# Altium schematic sheet 000339.SchDoc(000339)
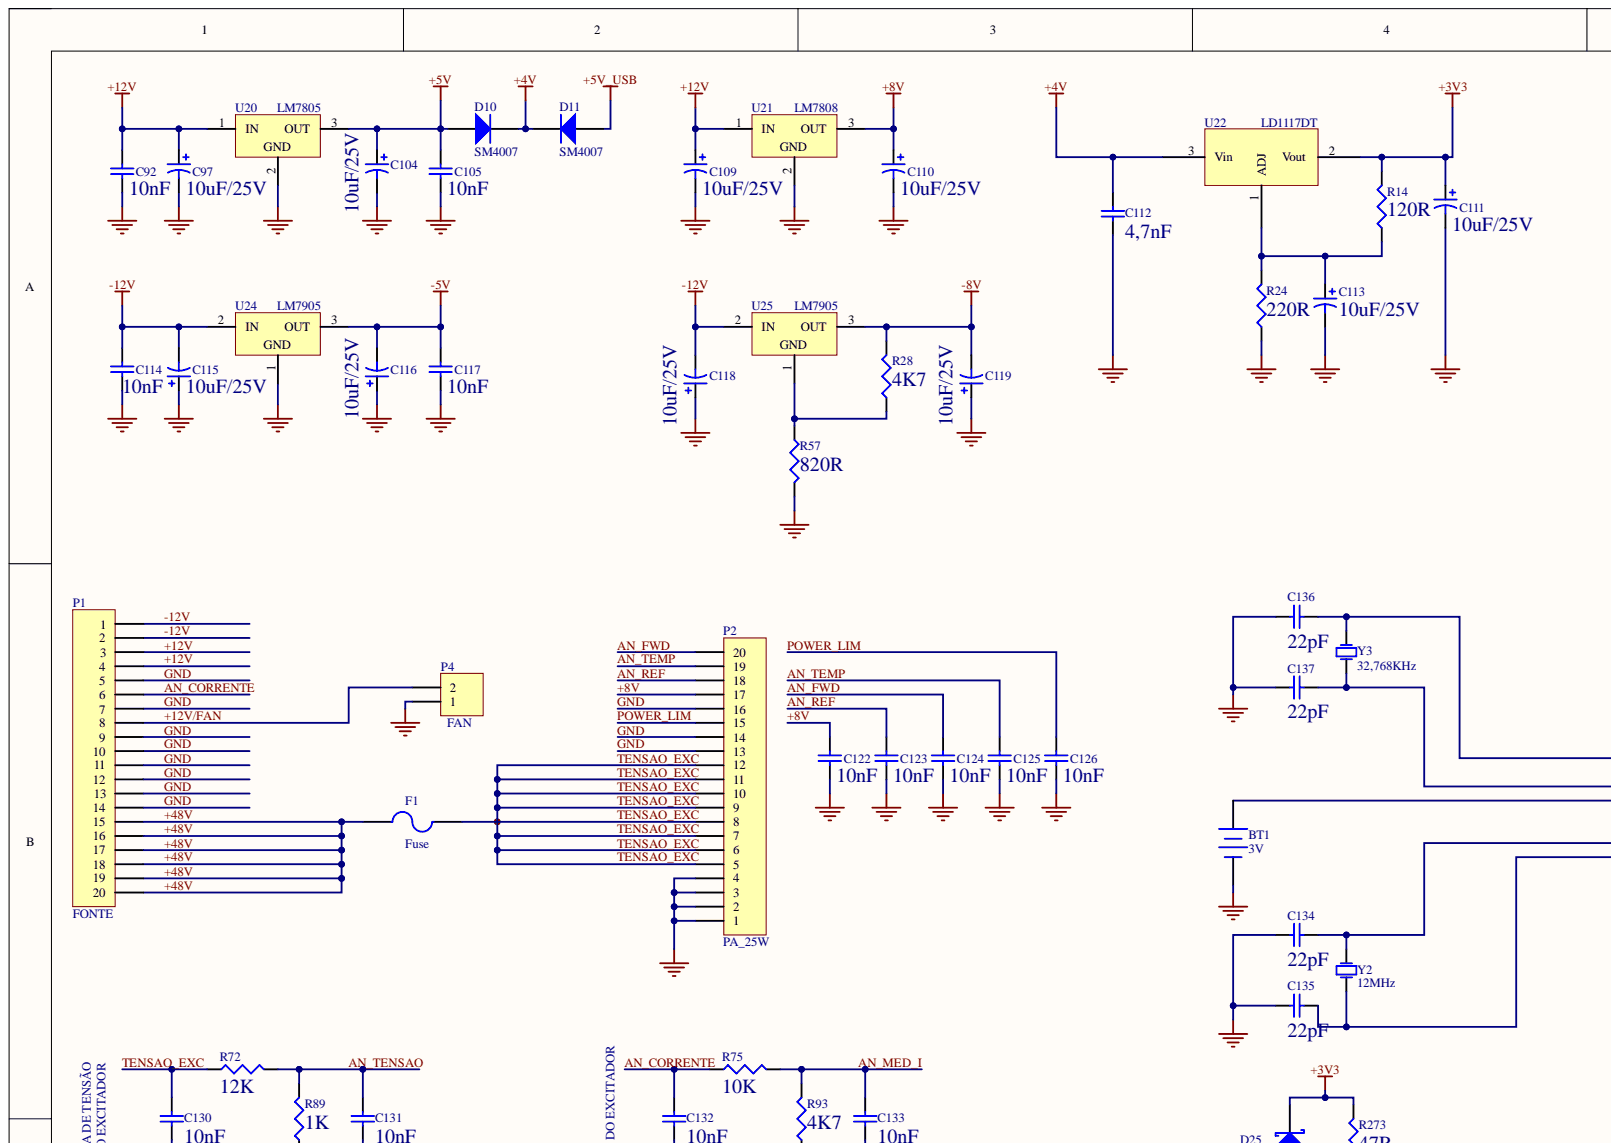
[diagram p0: 000339.SchDoc, top left]
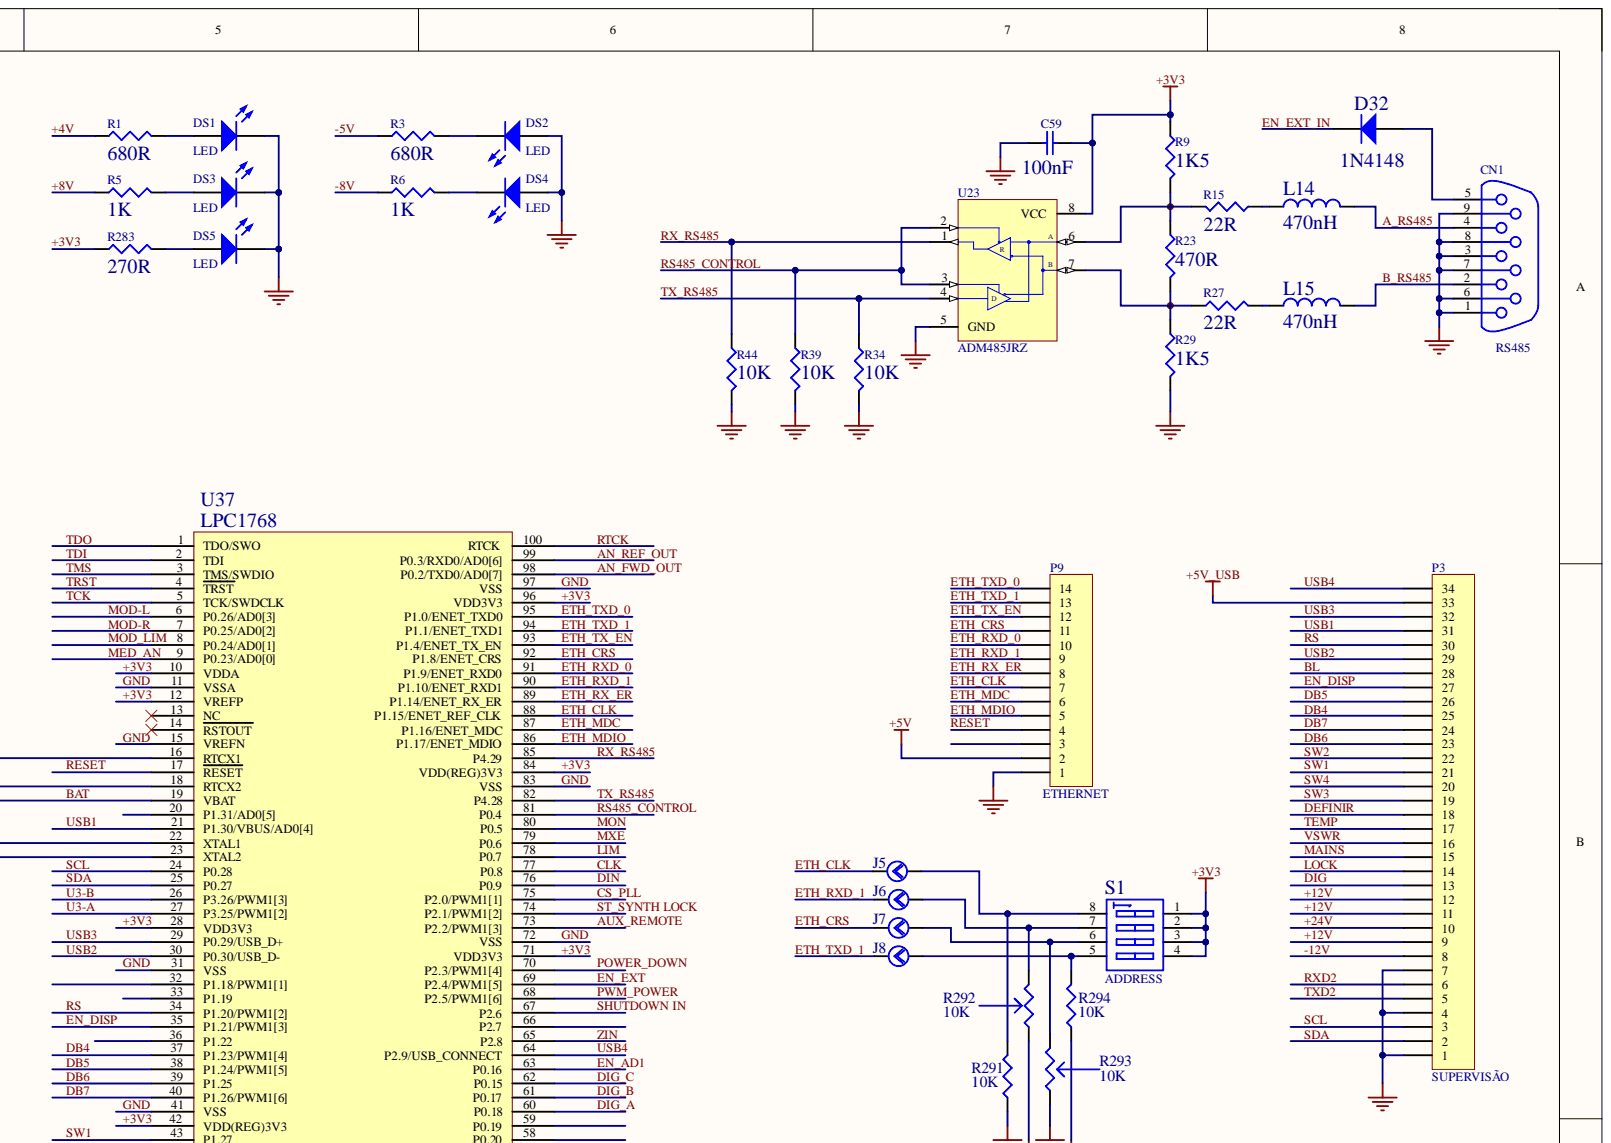
[diagram p1: 000339.SchDoc, top right]
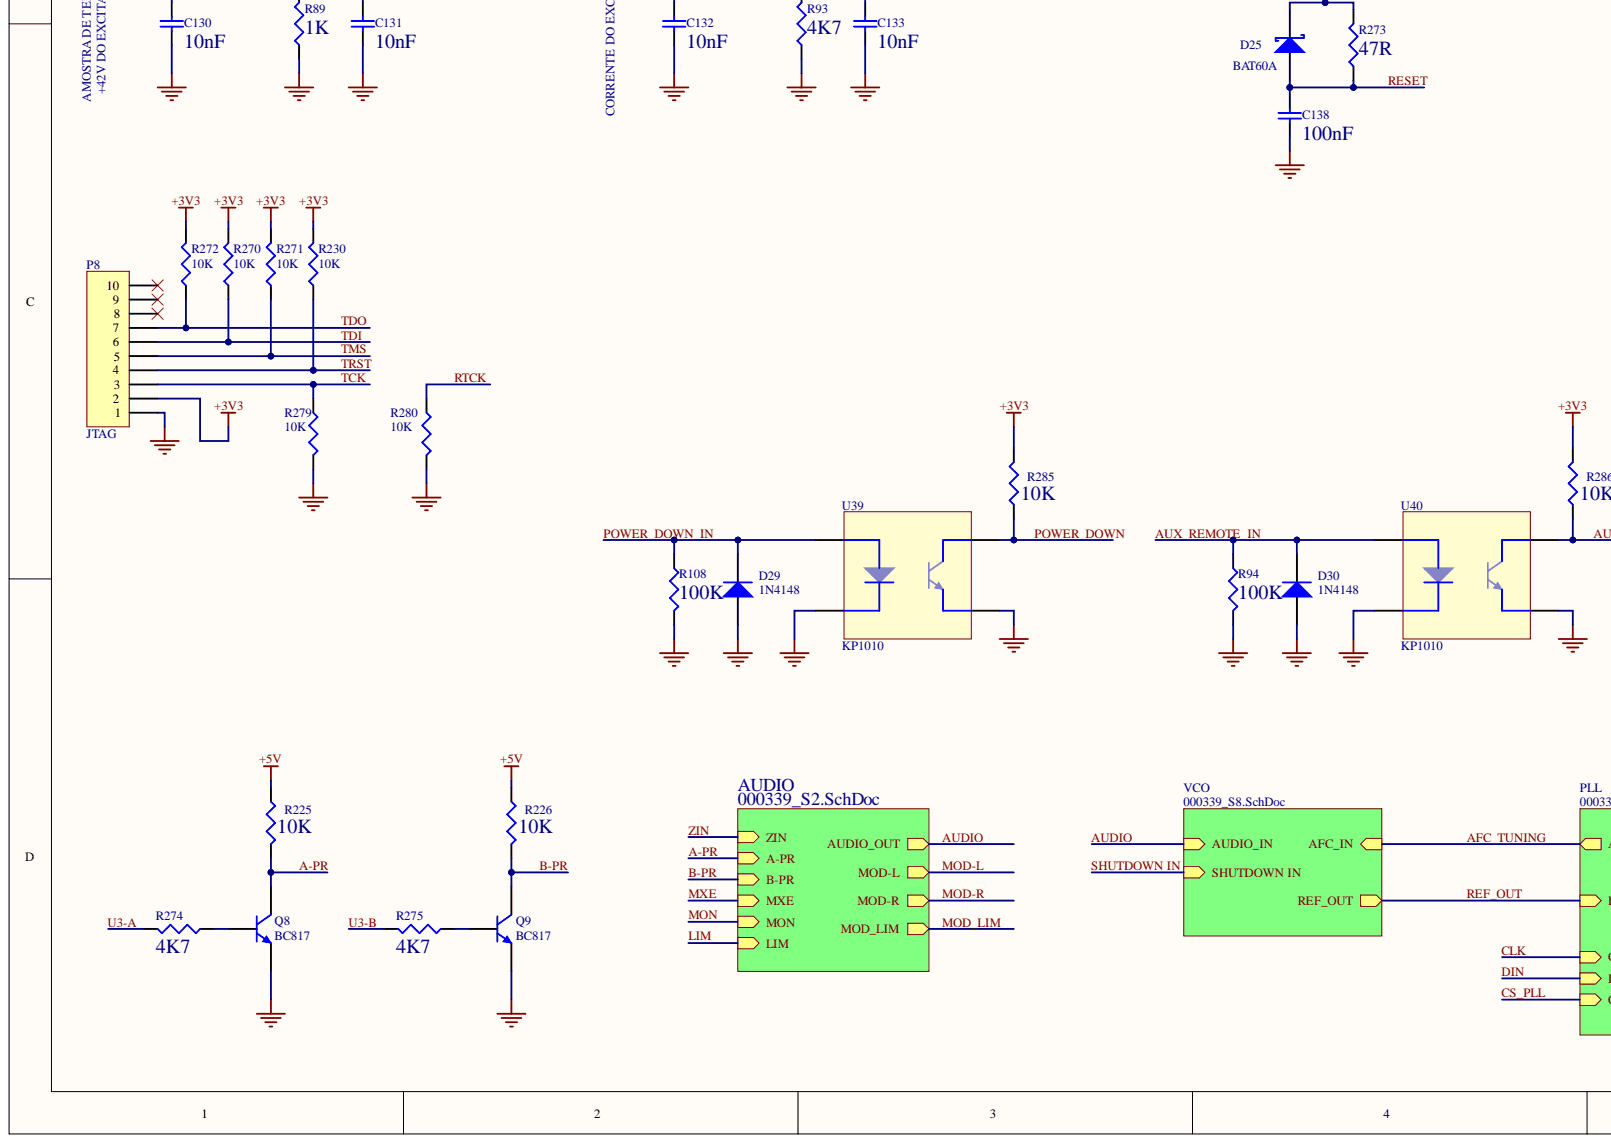
[diagram p2: 000339.SchDoc, bottom left]
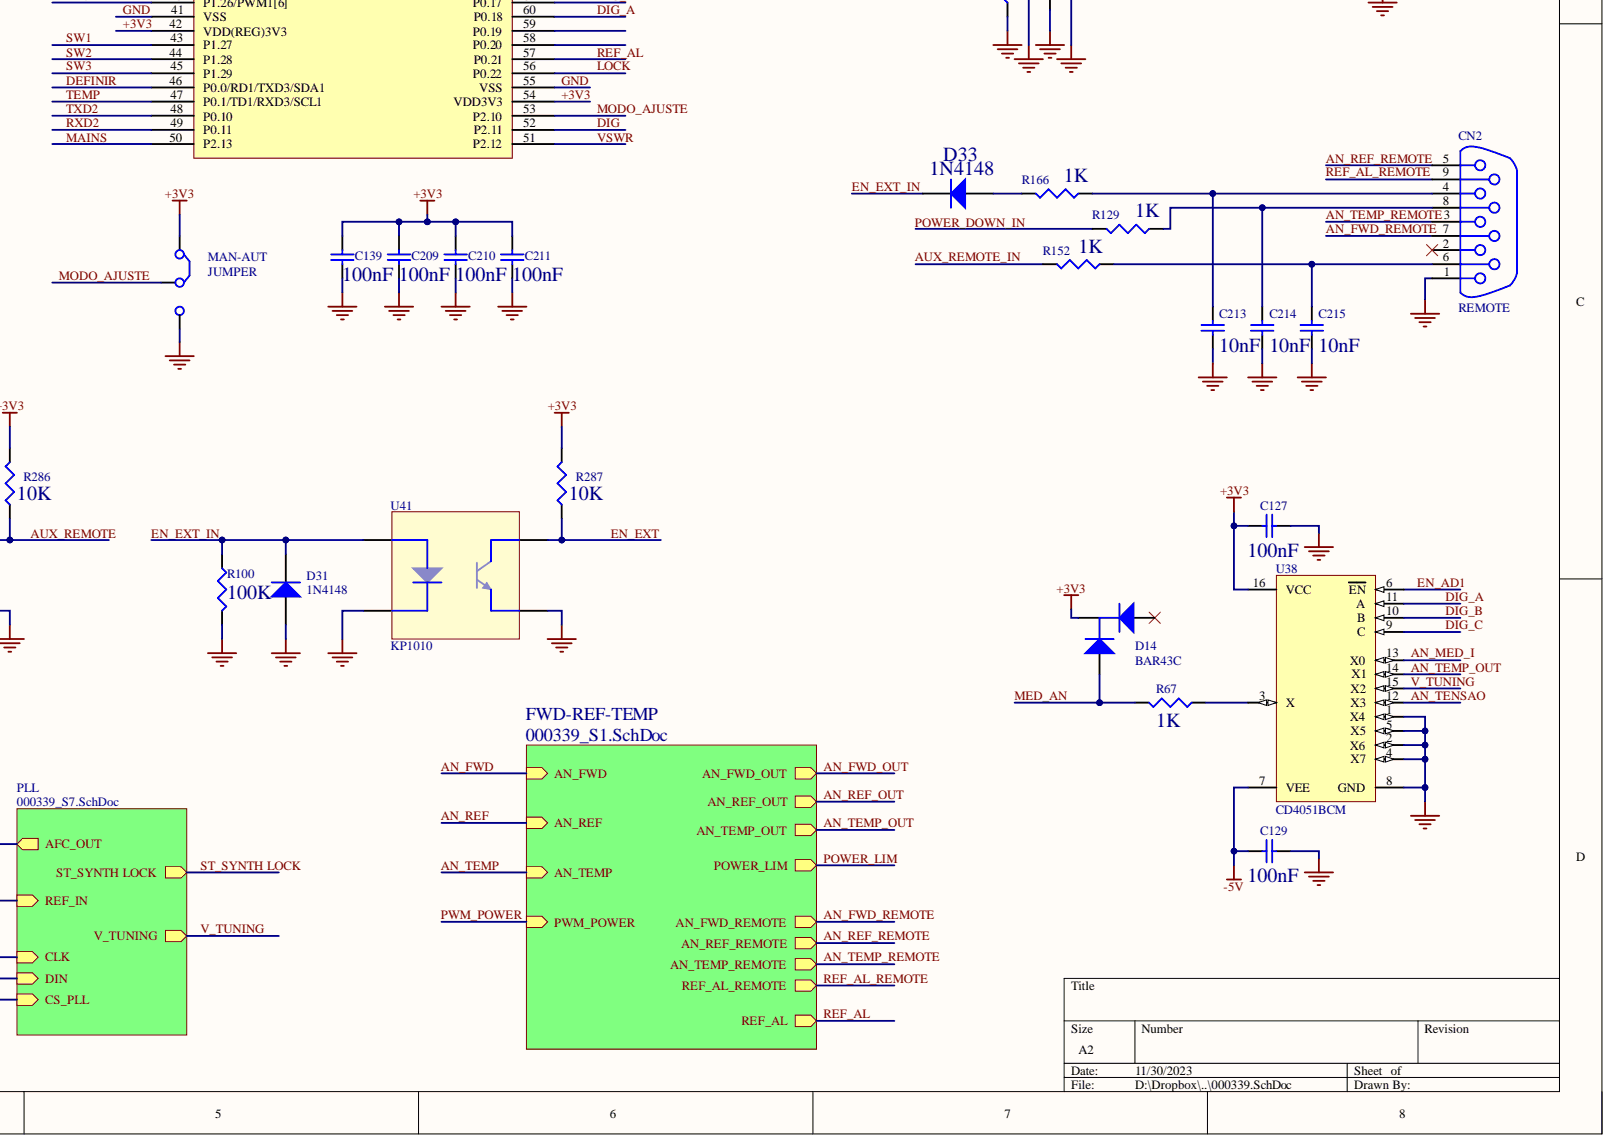
[diagram p3: 000339.SchDoc, bottom right]

## Parts
BT1  3V  [batery holder]
C59  value 100nF  [1206 - Capacitor]  (hidden comment: Capacitor)
C92  value 10nF  [1206 - Capacitor]  (hidden comment: Capacitor)
C97  value 10uF/25V  [ELETROLITICO C5x10]  (hidden comment: Capacitor Polarizado)
C104  value 10uF/25V  [ELETROLITICO C5x10]  (hidden comment: Capacitor Polarizado)
C105  value 10nF  [1206 - Capacitor]  (hidden comment: Capacitor)
C109  value 10uF/25V  [ELETROLITICO C5x10]  (hidden comment: Capacitor Polarizado)
C110  value 10uF/25V  [ELETROLITICO C5x10]  (hidden comment: Capacitor Polarizado)
C111  value 10uF/25V  [ELETROLITICO C5x10]  (hidden comment: Capacitor Polarizado)
C112  value 4,7nF  [1206 - Capacitor]  (hidden comment: Capacitor)
C113  value 10uF/25V  [ELETROLITICO C5x10]  (hidden comment: Capacitor Polarizado)
C114  value 10nF  [1206 - Capacitor]  (hidden comment: Capacitor)
C115  value 10uF/25V  [ELETROLITICO C5x10]  (hidden comment: Capacitor Polarizado)
C116  value 10uF/25V  [ELETROLITICO C5x10]  (hidden comment: Capacitor Polarizado)
C117  value 10nF  [1206 - Capacitor]  (hidden comment: Capacitor)
C118  value 10uF/25V  [ELETROLITICO C5x10]  (hidden comment: Capacitor Polarizado)
C119  value 10uF/25V  [ELETROLITICO C5x10]  (hidden comment: Capacitor Polarizado)
C122  value 10nF  [1206 - Capacitor]  (hidden comment: Capacitor)
C123  value 10nF  [1206 - Capacitor]  (hidden comment: Capacitor)
C124  value 10nF  [1206 - Capacitor]  (hidden comment: Capacitor)
C125  value 10nF  [1206 - Capacitor]  (hidden comment: Capacitor)
C126  value 10nF  [1206 - Capacitor]  (hidden comment: Capacitor)
C127  value 100nF  [1206 - Capacitor]  (hidden comment: Capacitor)
C129  value 100nF  [1206 - Capacitor]  (hidden comment: Capacitor)
C130  value 10nF  [1206 - Capacitor]  (hidden comment: Capacitor)
C131  value 10nF  [1206 - Capacitor]  (hidden comment: Capacitor)
C132  value 10nF  [1206 - Capacitor]  (hidden comment: Capacitor)
C133  value 10nF  [1206 - Capacitor]  (hidden comment: Capacitor)
C134  value 22pF  [1206 - Capacitor]  (hidden comment: Capacitor)
C135  value 22pF  [1206 - Capacitor]  (hidden comment: Capacitor)
C136  value 22pF  [1206 - Capacitor]  (hidden comment: Capacitor)
C137  value 22pF  [1206 - Capacitor]  (hidden comment: Capacitor)
C138  value 100nF  [1206 - Capacitor]  (hidden comment: Capacitor)
C139  value 100nF  [1206 - Capacitor]  (hidden comment: Capacitor)
C209  value 100nF  [1206 - Capacitor]  (hidden comment: Capacitor)
C210  value 100nF  [1206 - Capacitor]  (hidden comment: Capacitor)
C211  value 100nF  [1206 - Capacitor]  (hidden comment: Capacitor)
C213  value 10nF  [1206 - Capacitor]  (hidden comment: Capacitor)
C214  value 10nF  [1206 - Capacitor]  (hidden comment: Capacitor)
C215  value 10nF  [1206 - Capacitor]  (hidden comment: Capacitor)
CN1  RS485  [DB 9 FEMEA 90G]
CN2  REMOTE  [DB 9 FEMEA 90G]
D10  value SM4007  PART #: SM4007  [DO-213AB]  (hidden comment: MRA/SM4007)
D11  value SM4007  PART #: SM4007  [DO-213AB]  (hidden comment: MRA/SM4007)
D14  BAR43C  [SOT23]
D25  BAT60A  [SOD323]
D29  1N4148  [1N4148 - SMD]
D30  1N4148  [1N4148 - SMD]
D31  1N4148  [1N4148 - SMD]
D32  1N4148  [1N4148 - SMD]
D33  1N4148  [1N4148 - SMD]
DS1  LED  [LED 1206]
DS2  LED  [LED 1206]
DS3  LED  [LED 1206]
DS4  LED  [LED 1206]
DS5  LED  [LED 1206]
F1  Fuse  [PORTA FUSIVEL]
J5  JUNCTION  [JUNCTION]  (value not drawn)
J6  JUNCTION  [JUNCTION]  (value not drawn)
J7  JUNCTION  [JUNCTION]  (value not drawn)
J8  JUNCTION  [JUNCTION]  (value not drawn)
L14  value 470nH  [1210 Indutor]  (hidden comment: Inductor Iron)
L15  value 470nH  [1210 Indutor]  (hidden comment: Inductor Iron)
MAN-AUT  JUMPER  [HDR1X3]
P1  FONTE  [IDC 20 VIAS 180 GRAUS]
P2  PA_25W  [IDC 20 VIAS 180 GRAUS]
P3  SUPERVISÃO  [IDC 34 VIAS 180 GRAUS]
P4  FAN  [KRE_2_VIAS]
P8  JTAG  [HDR2X5]
P9  ETHERNET  [HDR2X7]
Q8  BC817  [SOT23]
Q9  BC817  [SOT23]
R1  value 680R  [1206 - Resistor]  (hidden comment: Resistor)
R3  value 680R  [1206 - Resistor]  (hidden comment: Resistor)
R5  value 1K  [1206 - Resistor]  (hidden comment: Resistor)
R6  value 1K  [1206 - Resistor]  (hidden comment: Resistor)
R9  value 1K5  [1206 - Resistor]  (hidden comment: Resistor)
R14  value 120R  [1206 - Resistor]  (hidden comment: Resistor)
R15  value 22R  [1206 - Resistor]  (hidden comment: Resistor)
R23  value 470R  [1206 - Resistor]  (hidden comment: Resistor)
R24  value 220R  [1206 - Resistor]  (hidden comment: Resistor)
R27  value 22R  [1206 - Resistor]  (hidden comment: Resistor)
R28  value 4K7  [1206 - Resistor]  (hidden comment: Resistor)
R29  value 1K5  [1206 - Resistor]  (hidden comment: Resistor)
R34  value 10K  [1206 - Resistor]  (hidden comment: Resistor)
R39  value 10K  [1206 - Resistor]  (hidden comment: Resistor)
R44  value 10K  [1206 - Resistor]  (hidden comment: Resistor)
R57  value 820R  [1206 - Resistor]  (hidden comment: Resistor)
R67  value 1K  [1206 - Resistor]  (hidden comment: Resistor)
R72  value 12K  [1206 - Resistor]  (hidden comment: Resistor)
R75  value 10K  [1206 - Resistor]  (hidden comment: Resistor)
R89  value 1K  [1206 - Resistor]  (hidden comment: Resistor)
R93  value 4K7  [1206 - Resistor]  (hidden comment: Resistor)
R94  value 100K  [1206 - Resistor]  (hidden comment: Resistor)
R100  value 100K  [1206 - Resistor]  (hidden comment: Resistor)
R108  value 100K  [1206 - Resistor]  (hidden comment: Resistor)
R129  value 1K  [1206 - Resistor]  (hidden comment: Resistor)
R152  value 1K  [1206 - Resistor]  (hidden comment: Resistor)
R166  value 1K  [1206 - Resistor]  (hidden comment: Resistor)
R225  value 10K  [1206 - Resistor]  (hidden comment: Resistor)
R226  value 10K  [1206 - Resistor]  (hidden comment: Resistor)
R230  value 10K  [1206 - Resistor]  (hidden comment: Resistor)
R270  value 10K  [1206 - Resistor]  (hidden comment: Resistor)
R271  value 10K  [1206 - Resistor]  (hidden comment: Resistor)
R272  value 10K  [1206 - Resistor]  (hidden comment: Resistor)
R273  value 47R  [1206 - Resistor]  (hidden comment: Resistor)
R274  value 4K7  [1206 - Resistor]  (hidden comment: Resistor)
R275  value 4K7  [1206 - Resistor]  (hidden comment: Resistor)
R279  value 10K  [1206 - Resistor]  (hidden comment: Resistor)
R280  value 10K  [1206 - Resistor]  (hidden comment: Resistor)
R283  value 270R  [1206 - Resistor]  (hidden comment: Resistor)
R285  value 10K  [1206 - Resistor]  (hidden comment: Resistor)
R286  value 10K  [1206 - Resistor]  (hidden comment: Resistor)
R287  value 10K  [1206 - Resistor]  (hidden comment: Resistor)
R291  value 10K  [1206 - Resistor]  (hidden comment: Resistor)
R292  value 10K  [1206 - Resistor]  (hidden comment: Resistor)
R293  value 10K  [1206 - Resistor]  (hidden comment: Resistor)
R294  value 10K  [1206 - Resistor]  (hidden comment: Resistor)
S1  ADDRESS  [DIPSWICH-4]
U20  LM7805  [TO-220AB]
U21  LM7808  [TO-220AB]
U22  LD1117DT  [LD1117DT]
U23  ADM485JRZ  [SO8 - 3D]
U24  LM7905  [TO-220AB]
U25  LM7905  [TO-220AB]
U37  LPC1768  [LPC1768-FBD100]
U38  CD4051BCM  [M16A]
U39  KP1010  [SOP-4]
U40  KP1010  [SOP-4]
U41  KP1010  [SOP-4]
Y2  12MHz  [HC-49/U]
Y3  32,768KHz  [XTAL 32.768 Khz]

## Nets
+5V: C104.1 C105.2 D10.1 P9.2 R225.1 R226.1 U20.3
+5V_USB: D11.1 P3.33
+3V3: C59.1 C111.1 C127.2 C139.2 C209.2 C210.2 C211.2 D14.3 D25.1 MAN-AUT.1 P8.2 R9.2 R14.2 R230.2 R270.2 R271.2 R272.2 R273.2 R283.1 R285.2 R286.2 R287.2 S1.1 S1.2 S1.3 S1.4 U22.2 U23.8(VCC) U37.10(VDDA) U37.12(VREFP) U37.28(VDD3V3) U37.42(VDD(REG)3V3) U37.54(VDD3V3) U37.71(VDD3V3) U37.84(VDD(REG)3V3) U37.96(VDD3V3) U38.16(VCC)
+4V: C112.2 D10.2 D11.2 R1.1 U22.3
+8V: C110.1 C122.2 P2.17 R5.1 U21.3
+12V: C92.2 C97.1 C109.1 P1.3 P1.4 P3.9 P3.11 P3.12 U20.1 U21.1
+12V/FAN: P1.8 P4.2
+24V: P3.10
+48V: F1.1 P1.15 P1.16 P1.17 P1.18 P1.19 P1.20
-5V: C116.2 C117.2 C129.1 R3.1 U24.3 U38.7(VEE)
-8V: C119.2 R6.1 R28.2 U25.3
-12V: C114.2 C115.2 C118.2 P1.1 P1.2 P3.8 U24.2 U25.2
A-PR: Q8.3 R225.2
A_RS485: CN1.4 L14.2
AN_CORRENTE: C132.2 P1.6 R75.1
AN_FWD: C124.2 P2.20
AN_FWD_OUT: U37.98(P0.2/TXD0/AD0[7])
AN_FWD_REMOTE: CN2.7
AN_MED_I: C133.2 R75.2 R93.2 U38.13(X0)
AN_REF: C123.2 P2.18
AN_REF_OUT: U37.99(P0.3/RXD0/AD0[6])
AN_REF_REMOTE: CN2.5
AN_TEMP: C125.2 P2.19
AN_TEMP_OUT: U38.14(X1)
AN_TEMP_REMOTE: CN2.3
AN_TENSAO: C131.2 R72.2 R89.2 U38.12(X3)
AUX_REMOTE: R286.1 U37.73(P2.2/PWM1[3]) U40.4
AUX_REMOTE_IN: D30.2 R94.2 R152.1 U40.1
B-PR: Q9.3 R226.2
B_RS485: CN1.2 L15.2
BAT: BT1.1 U37.19(VBAT)
BL: P3.28
CLK: U37.77(P0.8)
CS_PLL: U37.75(P2.0/PWM1[1])
DB4: P3.25 U37.37(P1.23/PWM1[4])
DB5: P3.26 U37.38(P1.24/PWM1[5])
DB6: P3.23 U37.39(P1.25)
DB7: P3.24 U37.40(P1.26/PWM1[6])
DEFINIR: P3.18 U37.46(P0.0/RD1/TXD3/SDA1)
DIG: P3.13 U37.52(P2.11)
DIG_A: U37.60(P0.18) U38.11(A)
DIG_B: U37.61(P0.17) U38.10(B)
DIG_C: U37.62(P0.15) U38.9(C)
DIN: U37.76(P0.9)
EN_AD1: U37.63(P0.16) U38.6(EN)
EN_DISP: P3.27 U37.35(P1.21/PWM1[3])
EN_EXT: R287.1 U37.69(P2.4/PWM1[5]) U41.4
EN_EXT_IN: D31.2 D32.2 D33.2 R100.2 U41.1
ETH_CLK: J5.2 P9.7 U37.88(P1.15/ENET_REF_CLK)
ETH_CRS: J7.2 P9.11 U37.92(P1.8/ENET_CRS)
ETH_MDC: P9.6 U37.87(P1.16/ENET_MDC)
ETH_MDIO: P9.5 U37.86(P1.17/ENET_MDIO)
ETH_RX_ER: P9.8 U37.89(P1.14/ENET_RX_ER)
ETH_RXD_0: P9.10 U37.91(P1.9/ENET_RXD0)
ETH_RXD_1: J6.2 P9.9 U37.90(P1.10/ENET_RXD1)
ETH_TX_EN: P9.12 U37.93(P1.4/ENET_TX_EN)
ETH_TXD_0: P9.14 U37.95(P1.0/ENET_TXD0)
ETH_TXD_1: J8.2 P9.13 U37.94(P1.1/ENET_TXD1)
GND: BT1.2 C59.2 C92.1 C97.2 C104.2 C105.1 C109.2 C110.2 C111.2 C112.1 C113.2 C114.1 C115.1 C116.1 C117.1 C118.1 C119.1 C122.1 C123.1 C124.1 C125.1 C126.1 C127.1 C129.2 C130.1 C131.1 C132.1 C133.1 C134.2 C135.2 C136.2 C137.2 C138.2 C139.1 C209.1 C210.1 C211.1 C213.1 C214.1 C215.1 CN1.1 CN1.3 CN1.6 CN1.7 CN1.8 CN1.9 CN2.1 D29.1 D30.1 D31.1 DS1.2 DS2.1 DS3.2 DS4.1 DS5.2 MAN-AUT.3 P1.5 P1.7 P1.9 P1.10 P1.11 P1.12 P1.13 P1.14 P2.1 P2.2 P2.3 P2.4 P2.13 P2.14 P2.16 P3.1 P3.4 P3.7 P4.1 P8.1 P9.1 Q8.2 Q9.2 R24.1 R29.1 R34.1 R39.1 R44.1 R57.2 R89.1 R93.1 R94.1 R100.1 R108.1 R279.2 R280.2 R291.2 R292.2 R293.2 R294.2 U20.2 U21.2 U23.5(GND) U24.1 U37.11(VSSA) U37.15(VREFN) U37.31(VSS) U37.41(VSS) U37.55(VSS) U37.72(VSS) U37.83(VSS) U37.97(VSS) U38.1(X4) U38.2(X6) U38.4(X7) U38.5(X5) U38.8(GND) U39.2 U39.3 U40.2 U40.3 U41.2 U41.3
LIM: U37.78(P0.7)
LOCK: P3.14 U37.56(P0.22)
MAINS: P3.15 U37.50(P2.13)
MED_AN: D14.1 R67.1 U37.9(P0.23/AD0[0])
MOD-L: U37.6(P0.26/AD0[3])
MOD-R: U37.7(P0.25/AD0[2])
MOD_LIM: U37.8(P0.24/AD0[1])
MODO_AJUSTE: MAN-AUT.2 U37.53(P2.10)
MON: U37.80(P0.5)
MXE: U37.79(P0.6)
POWER_DOWN: R285.1 U37.70(P2.3/PWM1[4]) U39.4
POWER_DOWN_IN: D29.2 R108.2 R129.1 U39.1
POWER_LIM: C126.2 P2.15
PWM_POWER: U37.68(P2.5/PWM1[6])
REF_AL: U37.57(P0.21)
REF_AL_REMOTE: CN2.9
RESET: C138.1 D25.2 P9.4 R273.1 U37.17(RESET)
RS: P3.30 U37.34(P1.20/PWM1[2])
RS485_CONTROL: R39.2 U23.2 U23.3 U37.81(P0.4)
RTCK: R280.1 U37.100(RTCK)
RX_RS485: R44.2 U23.1 U37.85(P4.29)
RXD2: P3.6 U37.49(P0.11)
SCL: P3.3 U37.24(P0.28)
SDA: P3.2 U37.25(P0.27)
SHUTDOWN IN: U37.67(P2.6)
ST_SYNTH LOCK: U37.74(P2.1/PWM1[2])
SW1: P3.21 U37.43(P1.27)
SW2: P3.22 U37.44(P1.28)
SW3: P3.19 U37.45(P1.29)
SW4: P3.20
TCK: P8.3 R279.1 U37.5(TCK/SWDCLK)
TDI: P8.6 R270.1 U37.2(TDI)
TDO: P8.7 R272.1 U37.1(TDO/SWO)
TEMP: P3.17 U37.47(P0.1/TD1/RXD3/SCL1)
TENSAO_EXC: C130.2 F1.2 P2.5 P2.6 P2.7 P2.8 P2.9 P2.10 P2.11 P2.12 R72.1
TMS: P8.5 R271.1 U37.3(TMS/SWDIO)
TRST: P8.4 R230.1 U37.4(TRST)
TX_RS485: R34.2 U23.4 U37.82(P4.28)
TXD2: P3.5 U37.48(P0.10)
U3-A: R274.2 U37.27(P3.25/PWM1[2])
U3-B: R275.2 U37.26(P3.26/PWM1[3])
USB1: P3.31 U37.21(P1.30/VBUS/AD0[4])
USB2: P3.29 U37.30(P0.30/USB_D-)
USB3: P3.32 U37.29(P0.29/USB_D+)
USB4: P3.34 U37.64(P2.9/USB_CONNECT)
V_TUNING: U38.15(X2)
VSWR: P3.16 U37.51(P2.12)
ZIN: U37.65(P2.8)
NetC113_1: C113.1 R14.1 R24.2 U22.1
NetC134_1: C134.1 U37.22(XTAL1) Y2.2
NetC135_1: C135.1 U37.23(XTAL2) Y2.1
NetC136_1: C136.1 U37.16(RTCX1) Y3.2
NetC137_1: C137.1 U37.18(RTCX2) Y3.1
NetC213_2: C213.2 CN2.4 R166.2
NetC214_2: C214.2 CN2.8 R129.2
NetC215_2: C215.2 CN2.6 R152.2
NetCN1_5: CN1.5 D32.1
NetCN2_2: CN2.2
NetD14_2: D14.2
NetD33_1: D33.1 R166.1
NetDS1_1: DS1.1 R1.2
NetDS2_2: DS2.2 R3.2
NetDS3_1: DS3.1 R5.2
NetDS4_2: DS4.2 R6.2
NetDS5_1: DS5.1 R283.2
NetJ5_1: J5.1 R291.1 S1.8
NetJ6_1: J6.1 R292.1 S1.7
NetJ7_1: J7.1 R293.1 S1.6
NetJ8_1: J8.1 R294.1 S1.5
NetL14_1: L14.1 R15.2
NetL15_1: L15.1 R27.1
NetP8_8: P8.8
NetP8_9: P8.9
NetP8_10: P8.10
NetP9_3: P9.3
NetQ8_1: Q8.1 R274.1
NetQ9_1: Q9.1 R275.1
NetR9_1: R9.1 R15.1 R23.2 U23.6(A)
NetR23_1: R23.1 R27.2 R29.2 U23.7
NetR28_1: R28.1 R57.1 U25.1
NetR67_2: R67.2 U38.3(X)
NetU37_13: U37.13(NC)
NetU37_14: U37.14(RSTOUT)
NetU37_20: U37.20(P1.31/AD0[5])
NetU37_32: U37.32(P1.18/PWM1[1])
NetU37_33: U37.33(P1.19)
NetU37_36: U37.36(P1.22)
NetU37_58: U37.58(P0.20)
NetU37_59: U37.59(P0.19)
NetU37_66: U37.66(P2.7)
AFC_TUNING:
AUDIO:
REF_OUT:

## Library data of the parts
BT1 = BATTERY: Multicell Battery; VALUE: BATERIA 3V; Component Kind: Standard; Library Name: STB_MarcosP.SCHLIB
C97 C104 C109-C111 C113 C115 C116 C118 C119 = Capacitor Polarizado: CAPACITOR ELETROLITICO; Component Kind: Standard; LatestRevisionDate: 17-Jul-2002; LatestRevisionNote: Re-released for DXP Platform.; Published: 8-Jun-2000; Library Name: STB_MarcosP.SCHLIB; Publisher: Altium Limited
CN1 CN2 = CONECTOR DB9 PCI: VALUE: DB9 PCI; Component Kind: Standard; Description: CONECTOR DB9 PCI; Library Name: STB_MarcosP.SCHLIB
D10 D11 = MRA/SM4007: 1 Amp General Purpose Rectifier; CODIGO STB: 110.906.004; Component Kind: Standard; Library Name: STB_MarcosP.SCHLIB
D14 = BAR43C: SCHOTTKY DIODES; CODIGO STB: 110.909.004; # PART: BAR43C; Component Kind: Standard; VALUE: BAR43C; Library Name: STB_MarcosP.SCHLIB
D25 = BAT60A: Silicon AF Schottky Rectifier Diode with Extreme Low VF Drop; CODIGO STB: 110.905.021; Component Kind: Standard; VALUE: BAT60A; Library Name: STB_MarcosP.SCHLIB
D29-D33 = 1N4148: DIODO 1N4148; # PART: FDL4148; CODIGO STB: 110.906.013; Component Kind: Standard; VALUE: 1N4148; Library Name: STB_MarcosP.SCHLIB
F1 = Fuse: VALUE: Porta Fusivel; Component Kind: Standard; Description: Fuse; Library Name: STB_MarcosP.SCHLIB
J5-J8 = JUNCTION: Jumper Wire; Component Kind: Standard; Library Name: STB_MarcosP.SCHLIB
L14 L15 = INDUTOR: Magnetic-Core Inductor; CODIGO STB: 111.307.018; Component Kind: Standard; Library Name: STB_MarcosP.SCHLIB
MAN-AUT = Jumper_3: Jumper Wire; Component Kind: Standard; LatestRevisionDate: 17-Jul-2002; LatestRevisionNote: Re-released for DXP Platform.; Published: 24-Mar-1999; Library Name: STB_MarcosP.SCHLIB; Publisher: Altium Limited
P1 P2 = Header 20: Header, 20-Pin; VALUE: 20 PINOS; Component Kind: Standard; LatestRevisionDate: 17-Jul-2002; LatestRevisionNote: Re-released for DXP Platform.; Library Name: STB_MarcosP.SCHLIB; Publisher: Altium Limited
P3 = Header 34: Header, 34-Pin; VALUE: 34 PINOS; Component Kind: Standard; LatestRevisionDate: 17-Jul-2002; LatestRevisionNote: Re-released for DXP Platform.; Library Name: STB_MarcosP.SCHLIB; Publisher: Altium Limited
P4 = KRE_2: KRE, 2-Pin; VALUE: KRE 2 Vias; Component Kind: Standard; Library Name: STB_MarcosP.SCHLIB
P8 = Header 10: Header, 10-Pin; VALUE: 10 PINOS; Component Kind: Standard; LatestRevisionDate: 17-Jul-2002; LatestRevisionNote: Re-released for DXP Platform.; Library Name: STB_MarcosP.SCHLIB; Publisher: Altium Limited
P9 = Header 14: Header, 14-Pin; VALUE: IDC 14 VIAS; Component Kind: Standard; Library Name: STB_MarcosP.SCHLIB
Q8 Q9 = BC817: NPN General-purpose Transistor; Component Kind: Standard; DatasheetDocument: Jun-1999; PackageDocument: May-1999; PackageReference: SOT23; Published: 17-Sep-2003; VALUE: BC817; Library Name: STB_MarcosP.SCHLIB; Publisher: Altium Limited
S1 = SW DIP-4: DIP Switch; CODIGO STB: //; VALUE: //; Component Kind: Standard; Library Name: STB_MarcosP.SCHLIB
U20 U21 = LM78XX: 3-Terminal Positive Voltage Regulator; Note: Case is connected to GND; PackageDocument: 2000; Component Kind: Standard; DatasheetDocument: Jul-1999; PackageReference: H03A; Published: 15-Apr-2002; Library Name: STB_MarcosP.SCHLIB; Publisher: Altium Limited
  U20: VALUE: LM7805
  U21: VALUE: LM7808
U22 = LD1117DT: Regulador de tensão; CODIGO STB: 110.120.019; VALUE: LD1117; Component Kind: Standard; Library Name: STB_MarcosP.SCHLIB
U23 = ADM485JRZ: Low-Power, Slew-Rate-Limited RS-485/RS-422 Transceiver; CODIGO STB: 110.107.001; VALUE: ADM485; # PART: ADM485JRZ; Component Kind: Standard; Library Name: STB_MarcosP.SCHLIB
U24 U25 = LM79M12: 3-Terminal Negative Voltage Regulator; Note: Case is connected to GND; PackageDocument: 2000; Component Kind: Standard; DatasheetDocument: Jul-1999; PackageReference: H03A; Published: 15-Apr-2002; VALUE: LM7905; Library Name: STB_MarcosP.SCHLIB; Publisher: Altium Limited
U37 = LPC1768 - C: 32-bit ARM Cortex-M3 microcontroller; up to 512 kB flash and  64 kB SRAM with Ethernet, USB 2.0 Host/Device/OTG, CAN; Component Kind: Standard; VALUE: LPC1768; Library Name: STB_MarcosP.SCHLIB
U38 = CD4051BCM: 8-Channel Analog Multiplexer/Demultiplexer; CODIGO STB: 110.113.001; VALUE: CD4051; # PART: CD4051BCM; Component Kind: Standard; Library Name: STB_MarcosP.SCHLIB
U39-U41 = KP1010: High Reliability Photo Coupler; Component Kind: Standard; VALUE: KP1010; Library Name: STB_MarcosP.SCHLIB
Y2 = Cristal: Crystal Oscillator; Component Kind: Standard; LatestRevisionDate: 17-Jul-2002; LatestRevisionNote: Re-released for DXP Platform.; Published: 8-Jun-2000; VALUE: 12MHz; Library Name: STB_MarcosP.SCHLIB; Publisher: Altium Limited
Y3 = Cristal: Crystal Oscillator; VALUE: Cristal 32,768KHz; Component Kind: Standard; LatestRevisionDate: 17-Jul-2002; LatestRevisionNote: Re-released for DXP Platform.; Published: 8-Jun-2000; Library Name: STB_MarcosP.SCHLIB; Publisher: Altium Limited
C59 C92 C105 C112 C114 C117 C122-C127 C129-C139 C209-C211 C213-C215 = Capacitor: Component Kind: Standard; Description: Capacitor; LatestRevisionDate: 17-Jul-2002; LatestRevisionNote: Re-released for DXP Platform.; Published: 8-Jun-2000; Library Name: STB_MarcosP.SCHLIB; Publisher: Altium Limited
DS1-DS5 = LED: Component Kind: Standard; Description: LED; VALUE: LED; Library Name: STB_MarcosP.SCHLIB
R1 R3 R5 R6 R9 R14 R15 R23 R24 R27-R29 R34 R39 R44 R57 R67 R72 R75 R89 R93 R94 R100 R108 R129 R152 R166 R225 R226 R230 R270-R275 R279 R280 R283 R285-R287 R291-R294 = Resistor: Component Kind: Standard; Description: Resistor; LatestRevisionDate: 17-Jul-2002; LatestRevisionNote: Re-released for DXP Platform.; Published: 8-Jun-2000; Library Name: STB_MarcosP.SCHLIB; Publisher: Altium Limited
